# Altium schematic sheet P09_DRV2605L Motor Driver.SchDoc
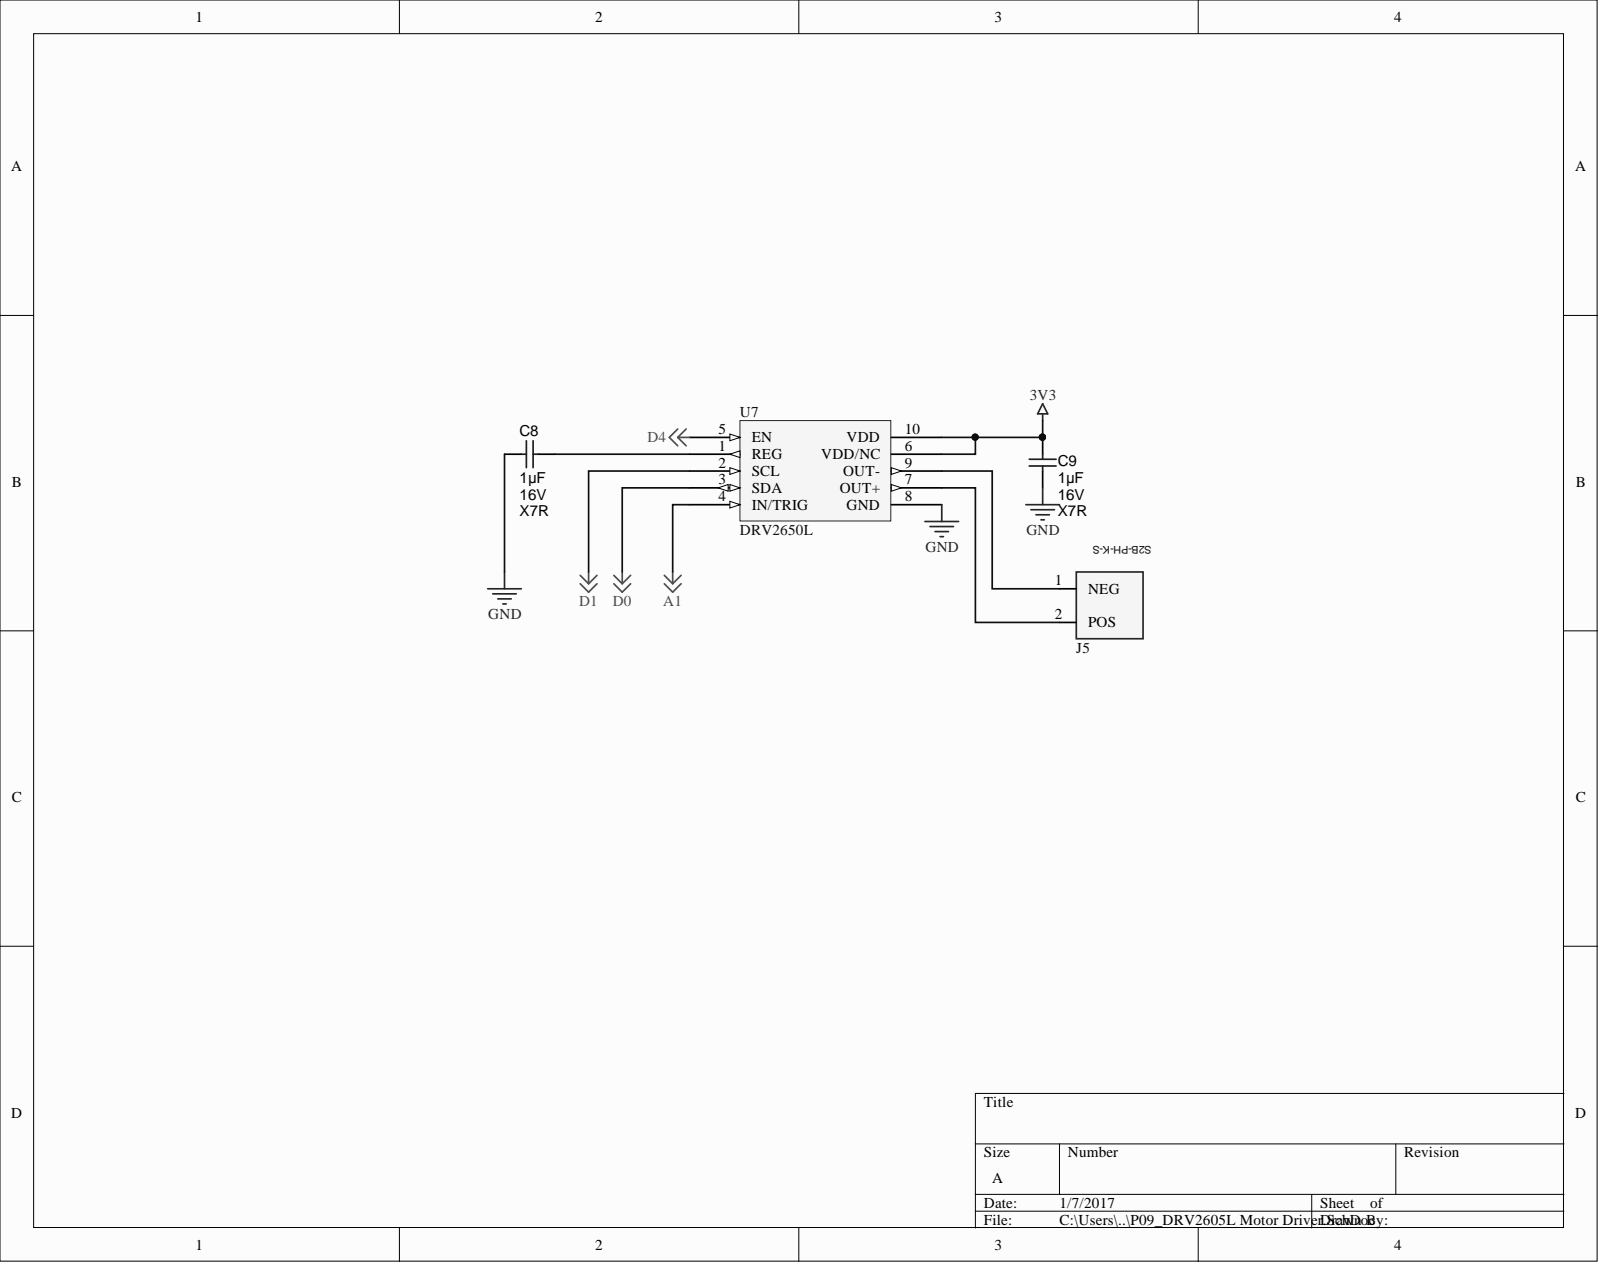
[diagram p0: P09_DRV2605L Motor Driver.SchDoc]

## Parts
C8  Value2: 16V  ValueDisplayed: 1µF  [0603]  (hidden comment: C1608X7R1C105K)
C9  TempCo: X7R  Value2: 16V  ValueDisplayed: 1µF  [0603]  (hidden comment: C1608X7R1C105K)
J5  S2B-PH-K-S  [S2B-PH-K-S]
U7  DRV2650L  [DGS0010A_N]

## Nets
3V3: C9.1 U7.6(VDD/NC) U7.10(VDD)
A1: U7.4(IN/TRIG)
D0: U7.3(SDA)
D1: U7.2(SCL)
D4: U7.5(EN)
GND: C8.2 C9.2 U7.8(GND)
NetC8_1: C8.1 U7.1(REG)
NetJ5_1: J5.1 U7.9(OUT-)
NetJ5_2: J5.2 U7.7(OUT+)

## Library data of the parts
C8 C9 = C1608X7R1C105K: CAP, CERM, 1 µF, 16 V, +/- 10%, X7R, 0603; Manufacturer: TDK; Cap_F: 0.000001; CompType: Capacitor; ESR_ohm: 0.0057; Polarized: N; TaMax_C: 125; TaMin_C: -55; Tolerance_%: 10; U/M: EA; Value: 1uF; Value1: 0.0057 ohm; VDC_V: 16; Cap_Case: 0603; Component Kind: Standard; ComponentLink1Description: Datasheet link; ComponentLink1URL: http://www.tdk.co.jp/tefe02/e412_c.pdf; LatestRevisionDate: 2014-09-22; Library Name: SLEEPTRACK2_PHASE3_Library.SchLib; MountType: SMT; PackageReference: 0603; PartNumber: C1608X7R1C105K; Published: 2008-06-19; RoHS: Y; Signal Integrity: Cap; Simulation: Cap; Supplier 1: Digi-Key; Supplier 2: Farnell; Supplier Part Number 1: 445-1604-1-ND; Supplier Part Number 2: 1907343; SymbolVersion: 2008-07-09; Technology: Ceramic; Publisher: Texas Instruments
  C8: TempCo: X7R
J5 = S2B-PH-K-S: 2 Positions Header, Shrouded Connector 0.079" (2.00mm) Through Hole, Right Angle Tin; Component Kind: Standard; Library Name: SLEEPTRACK2_PHASE3_Library.SchLib
U7 = DRV2650L: Component Kind: Standard; Library Name: SLEEPTRACK2_PHASE3_Library.SchLib
